# Altium schematic sheet solenoid.SchDoc
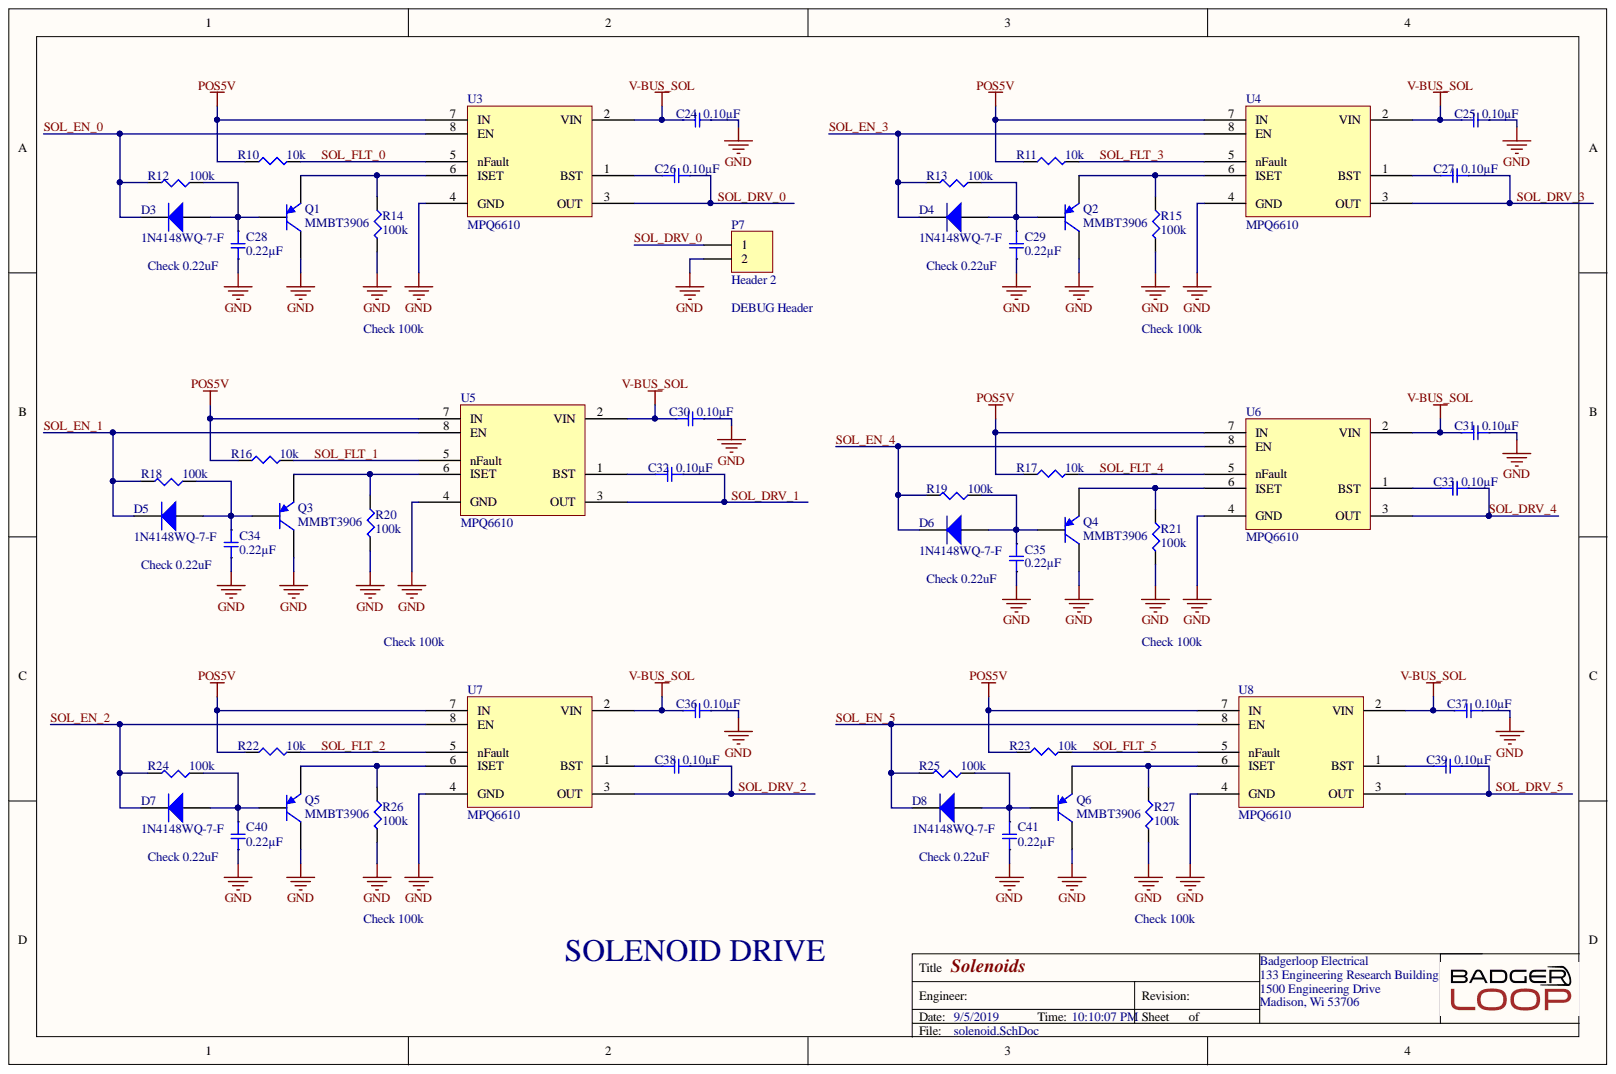
[diagram p0: solenoid.SchDoc]

## Parts
C24  0.10µF  [SMD-0805C]
C25  0.10µF  [SMD-0805C]
C26  0.10µF  [SMD-0805C]
C27  0.10µF  [SMD-0805C]
C28  0.22µF  [SMD-0805C]
C29  0.22µF  [SMD-0805C]
C30  0.10µF  [SMD-0805C]
C31  0.10µF  [SMD-0805C]
C32  0.10µF  [SMD-0805C]
C33  0.10µF  [SMD-0805C]
C34  0.22µF  [SMD-0805C]
C35  0.22µF  [SMD-0805C]
C36  0.10µF  [SMD-0805C]
C37  0.10µF  [SMD-0805C]
C38  0.10µF  [SMD-0805C]
C39  0.10µF  [SMD-0805C]
C40  0.22µF  [SMD-0805C]
C41  0.22µF  [SMD-0805C]
D3  1N4148WQ-7-F  [INDM3838X18N]
D4  1N4148WQ-7-F  [INDM3838X18N]
D5  1N4148WQ-7-F  [INDM3838X18N]
D6  1N4148WQ-7-F  [INDM3838X18N]
D7  1N4148WQ-7-F  [INDM3838X18N]
D8  1N4148WQ-7-F  [INDM3838X18N]
P7  Header 2  [Pin Header 1x2 TH Pitch 2.54mm]
Q1  MMBT3906  [SOTFL103P240X110-3N]
Q2  MMBT3906  [SOTFL103P240X110-3N]
Q3  MMBT3906  [SOTFL103P240X110-3N]
Q4  MMBT3906  [SOTFL103P240X110-3N]
Q5  MMBT3906  [SOTFL103P240X110-3N]
Q6  MMBT3906  [SOTFL103P240X110-3N]
R10  10k  [SMD-0805-RES]
R11  10k  [SMD-0805-RES]
R12  100k  [SMD-0805-RES]
R13  100k  [SMD-0805-RES]
R14  100k  [SMD-0805-RES]
R15  100k  [SMD-0805-RES]
R16  10k  [SMD-0805-RES]
R17  10k  [SMD-0805-RES]
R18  100k  [SMD-0805-RES]
R19  100k  [SMD-0805-RES]
R20  100k  [SMD-0805-RES]
R21  100k  [SMD-0805-RES]
R22  10k  [SMD-0805-RES]
R23  10k  [SMD-0805-RES]
R24  100k  [SMD-0805-RES]
R25  100k  [SMD-0805-RES]
R26  100k  [SMD-0805-RES]
R27  100k  [SMD-0805-RES]
U3  MPQ6610  [SOP127P600X175-8N]
U4  MPQ6610  [SOP127P600X175-8N]
U5  MPQ6610  [SOP127P600X175-8N]
U6  MPQ6610  [SOP127P600X175-8N]
U7  MPQ6610  [SOP127P600X175-8N]
U8  MPQ6610  [SOP127P600X175-8N]

## Nets
GND: C24.2 C25.2 C28.2 C29.2 C30.2 C31.2 C34.2 C35.2 C36.2 C37.2 C40.2 C41.2 P7.2 Q1.3 Q2.3 Q3.3 Q4.3 Q5.3 Q6.3 R14.2 R15.2 R20.2 R21.2 R26.2 R27.2 U3.4(GND) U4.4(GND) U5.4(GND) U6.4(GND) U7.4(GND) U8.4(GND)
POS5V: R10.1 R11.1 R16.1 R17.1 R22.1 R23.1 U3.7(IN) U4.7(IN) U5.7(IN) U6.7(IN) U7.7(IN) U8.7(IN)
V-BUS_SOL: C24.1 C25.1 C30.1 C31.1 C36.1 C37.1 U3.2(VIN) U4.2(VIN) U5.2(VIN) U6.2(VIN) U7.2(VIN) U8.2(VIN)
SOL_DRV_0: C26.2 P7.1 U3.3(OUT)
SOL_DRV_1: C32.2 U5.3(OUT)
SOL_DRV_2: C38.2 U7.3(OUT)
SOL_DRV_3: C27.2 U4.3(OUT)
SOL_DRV_4: C33.2 U6.3(OUT)
SOL_DRV_5: C39.2 U8.3(OUT)
SOL_EN_0: D3.2 R12.2 U3.8(EN)
SOL_EN_1: D5.2 R18.2 U5.8(EN)
SOL_EN_2: D7.2 R24.2 U7.8(EN)
SOL_EN_3: D4.2 R13.2 U4.8(EN)
SOL_EN_4: D6.2 R19.2 U6.8(EN)
SOL_EN_5: D8.2 R25.2 U8.8(EN)
SOL_FLT_0: R10.2 U3.5(nFault)
SOL_FLT_1: R16.2 U5.5(nFault)
SOL_FLT_2: R22.2 U7.5(nFault)
SOL_FLT_3: R11.2 U4.5(nFault)
SOL_FLT_4: R17.2 U6.5(nFault)
SOL_FLT_5: R23.2 U8.5(nFault)
NetC26_1: C26.1 U3.1(BST)
NetC27_1: C27.1 U4.1(BST)
NetC28_1: C28.1 D3.1 Q1.2 R12.1
NetC29_1: C29.1 D4.1 Q2.2 R13.1
NetC32_1: C32.1 U5.1(BST)
NetC33_1: C33.1 U6.1(BST)
NetC34_1: C34.1 D5.1 Q3.2 R18.1
NetC35_1: C35.1 D6.1 Q4.2 R19.1
NetC38_1: C38.1 U7.1(BST)
NetC39_1: C39.1 U8.1(BST)
NetC40_1: C40.1 D7.1 Q5.2 R24.1
NetC41_1: C41.1 D8.1 Q6.2 R25.1
NetQ1_1: Q1.1 R14.1 U3.6(ISET)
NetQ2_1: Q2.1 R15.1 U4.6(ISET)
NetQ3_1: Q3.1 R20.1 U5.6(ISET)
NetQ4_1: Q4.1 R21.1 U6.6(ISET)
NetQ5_1: Q5.1 R26.1 U7.6(ISET)
NetQ6_1: Q6.1 R27.1 U8.6(ISET)

## Library data of the parts
C24-C27 C30-C33 C36-C39 = GRM21B7U1A104JA01L: CAP CER 0.1UF 10V U2J 0805; Manufacturer: Murata Electronics North America; @ qty: 0; Minimum Quantity: 1; Tolerance: ±5%; Unit Price (USD): 0.4; Applications: General Purpose; Component Kind: Standard; Datasheets: http://www.murata.com/~/media/webrenewal/support/library/catalog/products/capacitor/mlcc/c02e.pdf; Digi-Key Part Number: 490-11592-1-ND; Factory Stock: 0; Features: -; Height - Seated (Max): -; Image: //media.digikey.com/Renders/Murata%20Renders/0805%20(2012%20Metric)%20.85mm.jpg; Lead Spacing: -; Lead Style: -; Library Name: capacitors.SchLib; Mounting Type: Surface Mount, MLCC; Operating Temperature: -55°C ~ 125°C; Package / Case: 0805 (2012 Metric); Packaging: Cut Tape (CT); Part Number: GRM21B7U1A104JA01L; Part Status: Active; Quantity Available: 3390; Ratings: -; Series: GRM; Size / Dimension: 0.079" L x 0.049" W (2.00mm x 1.25mm); Temperature Coefficient: U2J; Thickness (Max): 0.053" (1.35mm); Value: 0.10µF; Voltage: 10V
C28 C29 C34 C35 C40 C41 = LLA215R71A224MA14L: CAP CER 0.22UF 10V X7R 0805; Manufacturer: Murata Electronics North America; @ qty: 0; Minimum Quantity: 1; Tolerance: ±20%; Unit Price (USD): 0.36; Applications: Bypass, Decoupling; Component Kind: Standard; Datasheets: http://www.murata.com/~/media/webrenewal/support/library/catalog/products/capacitor/mlcc/c02e.pdf; Digi-Key Part Number: 490-4432-1-ND; Factory Stock: 0; Features: Low ESL (Multi-Terminal); Height - Seated (Max): -; Image: //media.digikey.com/Renders/Murata%20Renders/0805-LLA.jpg; Lead Spacing: -; Lead Style: -; Library Name: capacitors.SchLib; Mounting Type: Surface Mount, MLCC; Operating Temperature: -55°C ~ 125°C; Package / Case: 0805 (2012 Metric); Packaging: Cut Tape (CT); Part Number: LLA215R71A224MA14L; Part Status: Active; Quantity Available: 9914; Ratings: -; Series: LLA; Size / Dimension: 0.079" L x 0.049" W (2.00mm x 1.25mm); Temperature Coefficient: X7R; Thickness (Max): 0.022" (0.55mm); Value: 0.22µF; Voltage: 10V
D3-D8 = 1N4148WQ-7-F: Diodes - General Purpose, Power, Switching SMT Fast Switching Diode; Manufacturer: Diodes Inc.; Component Kind: Standard; Digikey Link: https://www.digikey.com/product-detail/en/diodes-incorporated/1N4148WQ-7-F/1N4148WQ-7-FDITR-ND/8136126; Digikey P/N: 1N4148WQ-7-FDITR-ND; Library Name: misc.SchLib; Manufacturer P/N: 1N4148WQ-7-F
P7 = Header 2: Header, 2-Pin; Component Kind: Standard; LatestRevisionDate: 17-Jul-2002; LatestRevisionNote: Re-released for DXP Platform.; Library Name: connector.SchLib; Signal Integrity: Connector; Publisher: Altium Limited
Q1-Q6 = MMBT3906-7-F: Manufacturer: Diodes Zetex; Collector Base Voltage (VCBO): 40V; Collector Emitter Saturation Voltage: -400mV; Continuous Collector Current: -200mA; Element Configuration: Single; Emitter Base Voltage (VEBO): 6V; Frequency: 250MHz; hFE Min: 100; Max Collector Current: 200mA; Max Power Dissipation: 300mW; Number of Elements: 1; Polarity: PNP; Voltage Rating (DC): -40V; Case/Package: SOT-23; Collector Emitter Breakdown Voltage: 40V; Collector Emitter Voltage (VCEO): 40V; Component Kind: Standard; ComponentLink1Description: https://datasheet.ciiva.com/27052/1540746-27052408.pdf?src-supplier=Jameco; ComponentLink1URL: https://datasheet.ciiva.com/27052/1540746-27052408.pdf?src-supplier=Jameco; ComponentLink2Description: https://datasheet.ciiva.com/15139/getdatasheetpartid-255292-15139821.pdf?src-supplier=Verical; ComponentLink2URL: https://datasheet.ciiva.com/15139/getdatasheetpartid-255292-15139821.pdf?src-supplier=Verical; Contact Plating: Tin,Matte; Current Rating: -200mA; Gain Bandwidth Product: 250MHz; Height: 1mm; Lead Free: Lead Free; Length: 3.05mm; Library Name: misc.SchLib; Manufacturer Part Number: MMBT3906-7-F; Max Breakdown Voltage: 40V; Max Frequency: 250MHz; Max Operating Temperature: 150°C; Min Operating Temperature: -55°C; Mount: Surface Mount; Number of Pins: 3; Package Quantity: 3000; Packaging: Tape and Reel; Power Dissipation: 300mW; Pricing 1: 1=0.12000, 10=0.11400, 100=0.06190, 500=0.03806, 1000=0.02596 (USD); Radiation Hardening: No; REACH SVHC: No SVHC; RoHS: Yes; Stock 1: 687580; Supplier 1: Digi-Key; Supplier Part Number 1: MMBT3906-FDICT-ND; Transition Frequency: 250MHz; Weight: 0.000282oz; Width: 1.4mm
R10 R11 R16 R17 R22 R23 = ERJ-P06J103V: RES SMD 10K OHM 5% 1/2W 0805; Manufacturer: Panasonic Electronic Components; @ qty: 1500; Factory Stock: 0; Minimum Quantity: 1; Power (Watts): 0.5W, 1/2W; Temperature Coefficient: ±200ppm/°C; Unit Price (USD): 0.01839; Component Kind: Standard; Composition: Thick Film; Datasheets: https://industrial.panasonic.com/cdbs/www-data/pdf/RDA0000/AOA0000C243.pdf; Digi-Key Part Number: P10KADCT-ND; Failure Rate: -; Features: Automotive AEC-Q200, Pulse Withstanding; Height - Seated (Max): 0.028" (0.70mm); Image: //media.digikey.com/Renders/Panasonic%20Renders/ERJ-0805%20Pkg.jpg; Library Name: resistors.SchLib; Number of Terminations: 2; Operating Temperature: -55°C ~ 155°C; Package / Case: 0805 (2012 Metric); Packaging: Cut Tape (CT); Part Number: ERJ-P06J103V; Part Status: Active; Quantity Available: 413576; Series: ERJ; Size / Dimension: 0.079" L x 0.049" W (2.00mm x 1.25mm); Supplier Device Package: 0805; Tolerance: ±5%; Value: 10k
R12-R15 R18-R21 R24-R27 = ERJ-P06J104V: RES SMD 100K OHM 5% 1/2W 0805; Manufacturer: Panasonic Electronic Components; @ qty: 1500; Factory Stock: 0; Minimum Quantity: 1; Power (Watts): 0.5W, 1/2W; Temperature Coefficient: ±200ppm/°C; Unit Price (USD): 0.01839; Component Kind: Standard; Composition: Thick Film; Datasheets: https://industrial.panasonic.com/cdbs/www-data/pdf/RDA0000/AOA0000C243.pdf; Digi-Key Part Number: P100KADCT-ND; Failure Rate: -; Features: Automotive AEC-Q200, Pulse Withstanding; Height - Seated (Max): 0.028" (0.70mm); Image: //media.digikey.com/Renders/Panasonic%20Renders/ERJ-0805%20Pkg.jpg; Library Name: resistors.SchLib; Number of Terminations: 2; Operating Temperature: -55°C ~ 155°C; Package / Case: 0805 (2012 Metric); Packaging: Cut Tape (CT); Part Number: ERJ-P06J104V; Part Status: Active; Quantity Available: 82264; Series: ERJ; Size / Dimension: 0.079" L x 0.049" W (2.00mm x 1.25mm); Supplier Device Package: 0805; Tolerance: ±5%; Value: 100k
U3-U8 = MPQ6610: Component Kind: Standard; Library Name: ic.SchLib
